# Altium schematic sheet temp_ext.SchDoc
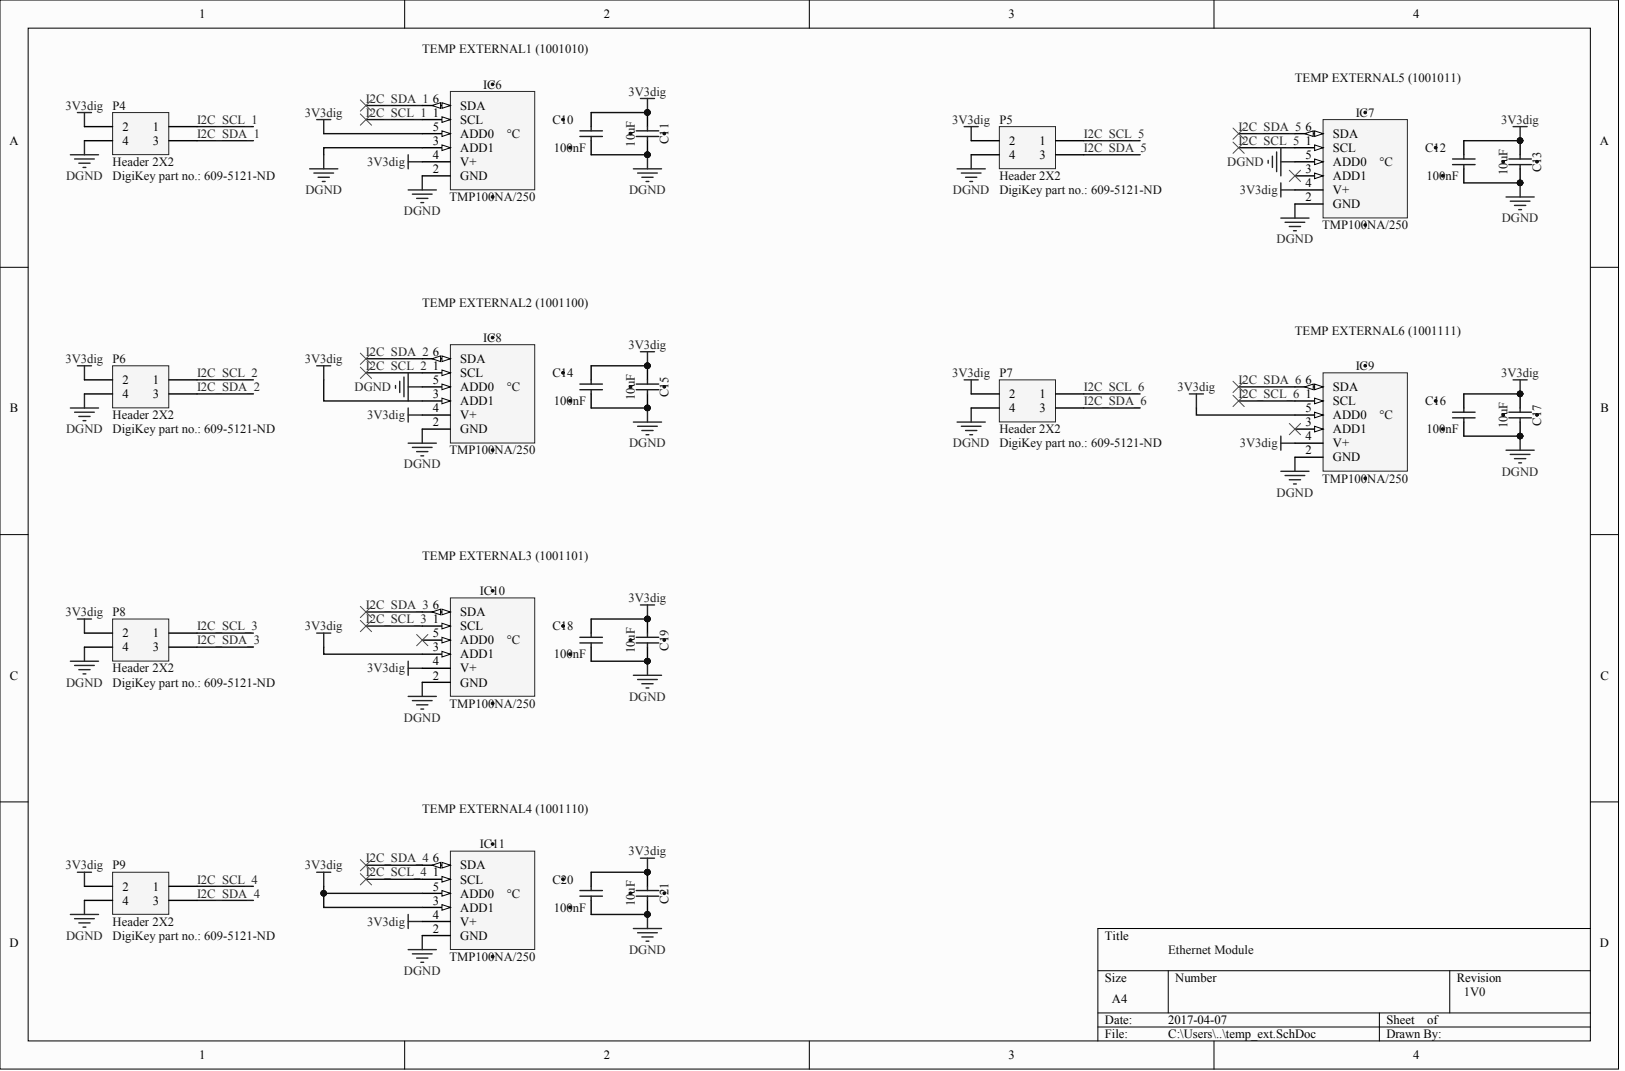
[diagram p0: temp_ext.SchDoc]

## Parts
C10  100nF  [CAPC1005X55N]
C11  10uF  [CAPC1608X87N]
C12  100nF  [CAPC1005X55N]
C13  10uF  [CAPC1608X87N]
C14  100nF  [CAPC1005X55N]
C15  10uF  [CAPC1608X87N]
C16  100nF  [CAPC1005X55N]
C17  10uF  [CAPC1608X87N]
C18  100nF  [CAPC1005X55N]
C19  10uF  [CAPC1608X87N]
C20  100nF  [CAPC1005X55N]
C21  10uF  [CAPC1608X87N]
IC6  TMP100NA/250  [SOT95P280X145-6N]
IC7  TMP100NA/250  [SOT95P280X145-6N]
IC8  TMP100NA/250  [SOT95P280X145-6N]
IC9  TMP100NA/250  [SOT95P280X145-6N]
IC10  TMP100NA/250  [SOT95P280X145-6N]
IC11  TMP100NA/250  [SOT95P280X145-6N]
P4  Header 2X2  DigiKey part no.: 609-5121-ND
P5  Header 2X2  DigiKey part no.: 609-5121-ND
P6  Header 2X2  DigiKey part no.: 609-5121-ND
P7  Header 2X2  DigiKey part no.: 609-5121-ND
P8  Header 2X2  DigiKey part no.: 609-5121-ND
P9  Header 2X2  DigiKey part no.: 609-5121-ND

## Nets
3V3DIG: C10.2 C11.2 C12.2 C13.2 C14.2 C15.2 C16.2 C17.2 C18.2 C19.2 C20.2 C21.2 IC6.4(V+) IC6.5(ADD0) IC7.4(V+) IC8.3(ADD1) IC8.4(V+) IC9.4(V+) IC9.5(ADD0) IC10.3(ADD1) IC10.4(V+) IC11.3(ADD1) IC11.4(V+) IC11.5(ADD0) P4.2 P5.2 P6.2 P7.2 P8.2 P9.2
DGND: C10.1 C11.1 C12.1 C13.1 C14.1 C15.1 C16.1 C17.1 C18.1 C19.1 C20.1 C21.1 IC6.2(GND) IC6.3(ADD1) IC7.2(GND) IC7.5(ADD0) IC8.2(GND) IC8.5(ADD0) IC9.2(GND) IC10.2(GND) IC11.2(GND) P4.4 P5.4 P6.4 P7.4 P8.4 P9.4
I2C_SCL_1: IC6.1(SCL) P4.1
I2C_SCL_2: IC8.1(SCL) P6.1
I2C_SCL_3: IC10.1(SCL) P8.1
I2C_SCL_4: IC11.1(SCL) P9.1
I2C_SCL_5: IC7.1(SCL) P5.1
I2C_SCL_6: IC9.1(SCL) P7.1
I2C_SDA_1: IC6.6(SDA) P4.3
I2C_SDA_2: IC8.6(SDA) P6.3
I2C_SDA_3: IC10.6(SDA) P8.3
I2C_SDA_4: IC11.6(SDA) P9.3
I2C_SDA_5: IC7.6(SDA) P5.3
I2C_SDA_6: IC9.6(SDA) P7.3

Not connected: IC7 3(ADD1); IC9 3(ADD1); IC10 5(ADD0)

## Library data of the parts
C10 C12 C14 C16 C18 C20 = (no symbol name): Part Number: CC0402_100NF_16V_10%_X7R; Part Description: SMD Multilayer Chip Ceramic Capacitor; Manufacturer: GENERIC; Manufacturer1 Part Number: GRM155R71C104KA88D; Voltage: 16V; TC: X7R; Tolerance: ±10%; Table: Capacitors SMD; Component Kind: Standard; Component Type: Standard; Pin Count: 2; Value: 100nF; Manufacturer Part Number: CC0402_100NF_16V_10%_X7R; Case: 0402; Mounted: Yes; Socket: No; SMD: Yes; Manufacturer1 Example: MURATA; Manufacturer1 ComponentHeight: 0.55mm; HelpURL: \\cern.ch\dfs\Applications\Altium\Datasheets\CC0402_X7R_MURATA_GRM.pdf; CreateDate: 05/12/2013; LatestRevisionDate: 03/12/2007; DigiKey part no.: 490-3261-1-ND; Author: CERN DEM JLC
C11 C13 C15 C17 C19 C21 = (no symbol name): Part Number: CC0603_10UF_6.3V_20%_X5R; Part Description: SMD Multilayer Chip Ceramic Capacitor; Manufacturer: GENERIC; Manufacturer Part Number: C0603C106M9PACTU; Voltage: 6.3V; TC: X5R; Tolerance: ±20%; Table: Capacitors SMD; Component Kind: Standard; Component Type: Standard; Pin Count: 2; Value: 10uF; Case: 0603; Mounted: Yes; Socket: No; SMD: Yes; Manufacturer1 Example: KEMET; Manufacturer1 ComponentHeight: 0.95mm; HelpURL: \\cern.ch\dfs\Applications\Altium\Datasheets\CC0603_X5R_KEMET.pdf; CreateDate: 03/12/2007; LatestRevisionDate: 03/12/2007; DigiKey part no.: 399-5504-1-ND; Author: CERN DEM JLC
IC6-IC11 = (no symbol name): Part Description: -55°C to +125°C, ±3.0°C  Accuracy, Digital Temperature Sensor with I2C Interface; Manufacturer: TEXAS INSTRUMENTS; Table: Sensors; Part Number: TMP100NA/250; Component Kind: Standard; Component Type: Standard; Device: TMP100NA/250; PackageDescription: SOT23, 6-Leads, Body 1.6x3.0mm, Pitch 0.95mm, IPC Medium Density; Manufacturer Part Number: TMP100NA/250; Pin Count: 6; Case: SOT23-6; Mounted: Yes; Socket: No; SMD: Yes; ComponentHeight: 1.45mm; HelpURL: \\cern.ch\dfs\Applications\Altium\Datasheets\TMP100NA-250.pdf; CreateDate: 29/10/2012; LatestRevisionDate: 29/10/2012; DigiKey part no.: 296-12749-1-ND; Author: CERN DEM JLC
P4-P9 = (no symbol name): Manufacturer part no.: 75869-330LF; LatestRevisionDate: 17-Jul-2002; LatestRevisionNote: Re-released for DXP Platform.; Publisher: Altium Limited
